# Altium schematic sheet Power v0.1.SchDoc
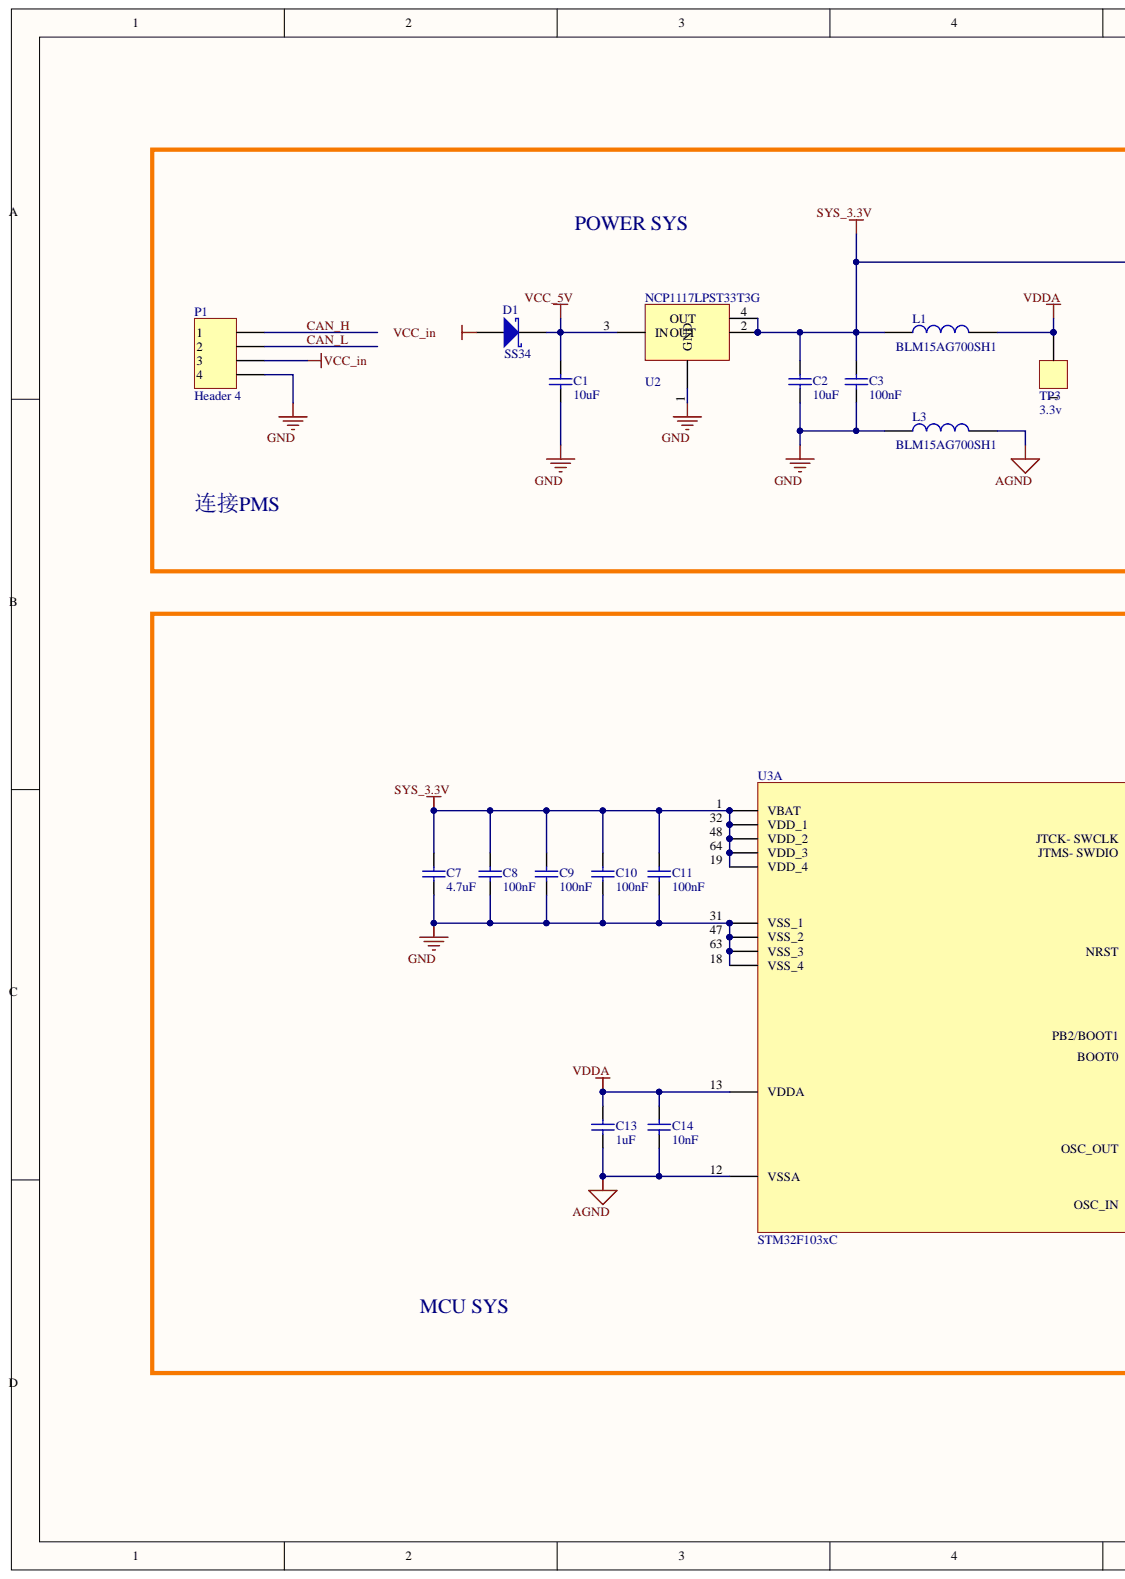
[diagram p0: Power v0.1.SchDoc, left]
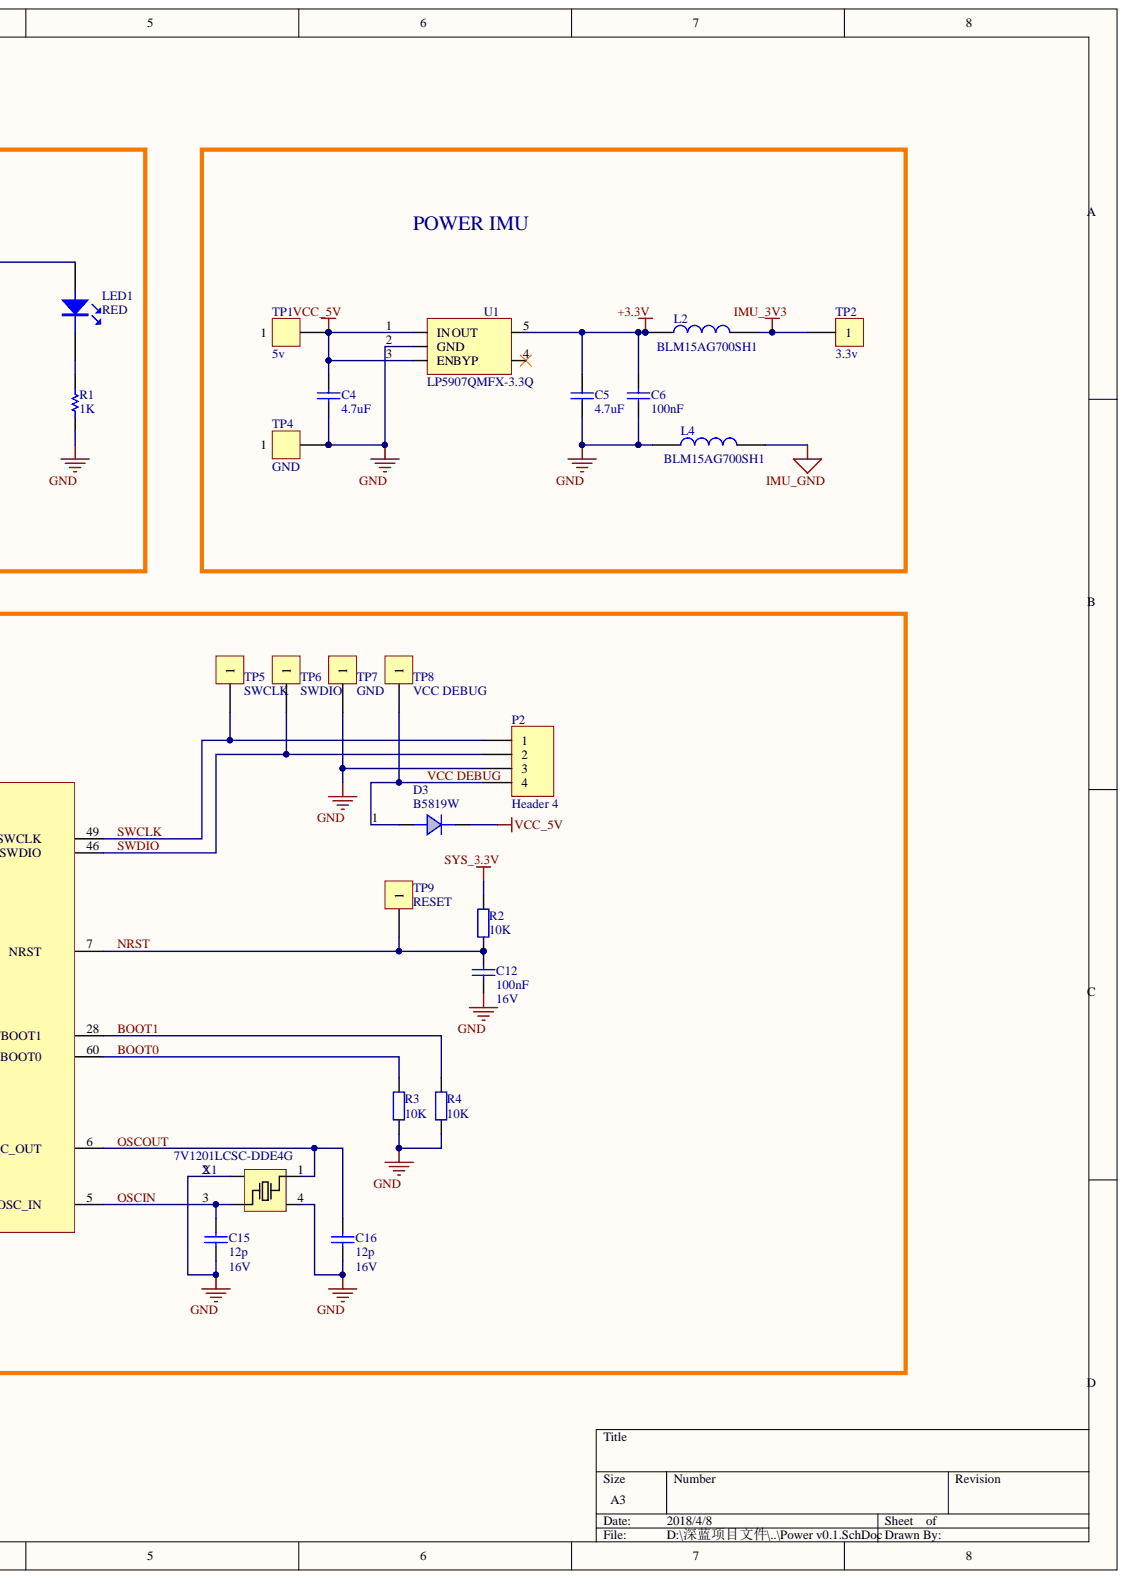
[diagram p1: Power v0.1.SchDoc, right]

## Parts
C1  value 10uF  [CAPC2012N]  (hidden comment: Cap)
C2  value 10uF  [CAPC1608N]  (hidden comment: Cap)
C3  value 100nF  [CAPC1608N]  (hidden comment: Cap)
C4  value 4.7uF  [CAPC1608N]  (hidden comment: Cap)
C5  value 4.7uF  [CAPC1608N]  (hidden comment: Cap)
C6  value 100nF  [CAPC1608N]  (hidden comment: Cap)
C7  value 4.7uF  [CAPC1608N]  (hidden comment: Cap)
C8  value 100nF  [CAPC1608N]  (hidden comment: Cap)
C9  value 100nF  [CAPC1608N]  (hidden comment: Cap)
C10  value 100nF  [CAPC1608N]  (hidden comment: Cap)
C11  value 100nF  [CAPC1608N]  (hidden comment: Cap)
C12  value 16V  value 100nF  [CAPC1608N]  (hidden comment: Cap)
C13  value 1uF  [CAPC1608N]  (hidden comment: Cap)
C14  value 10nF  [CAPC1608N]  (hidden comment: Cap)
C15  value 16V  value 12p  [CAPC1608N]  (hidden comment: Cap)
C16  value 16V  value 12p  [CAPC1608N]  (hidden comment: Cap)
D1  value SS34  [SMA]  (hidden comment: D Schottky)
D3  B5819W  [SOD-323]
L1  BLM15AG700SH1  [INDC1608N]
L2  BLM15AG700SH1  [INDC1608N]
L3  BLM15AG700SH1  [INDC1608N]
L4  BLM15AG700SH1  [INDC1608N]
LED1  RED  [LED_0603]
P1  Header 4  [1.25-4P-WT]
P2  Header 4  [1.25-4P-WT]
R1  value 1K  [RESC1608N]
R2  value 10K  [RESC1608N]  (hidden comment: Res2)
R3  value 10K  [RESC1608N]  (hidden comment: Res2)
R4  value 10K  [RESC1608N]  (hidden comment: Res2)
TP1  5v  [TP1.0-1]
TP2  3.3v  [TP1.0-1]
TP3  3.3v  [TP1.0-1]
TP4  GND  [TP1.0-1]
TP5  SWCLK  [TP1.0-1]
TP6  SWDIO  [TP1.0-1]
TP7  GND  [TP1.0-1]
TP8  VCC DEBUG  [TP1.0-1]
TP9  RESET  [TP1.0-1]
U1  LP5907QMFX-3.3Q  [SOT23-5]
U2  NCP1117LPST33T3G  [SOT223-4N]
U3  STM32F103xC  [TSQFP50P1200X1200-64M]  (part A)
X1  7V1201LCSC-DDE4G  [XTAL3225-4P]

## Nets
+3.3V: C5.1 C6.2 L2.1 U1.5
AGND: C13.2 C14.2 L3.2 U3.12(VSSA)
GND: C1.2 C2.2 C3.1 C4.2 C5.2 C6.1 C7.2 C8.2 C9.2 C10.2 C11.2 C12.2 C15.1 C16.1 L3.1 L4.1 P1.4 P2.3 R1.1 R3.1 R4.1 TP4.1 TP7.1 U1.2(GND) U2.1(GND) U3.18(VSS_4) U3.31(VSS_1) U3.47(VSS_2) U3.63(VSS_3) X1.2 X1.4
IMU_3V3: L2.2 TP2.1
IMU_GND: L4.2
SYS_3.3V: C2.1 C3.2 C7.1 C8.1 C9.1 C10.1 C11.1 L1.1 LED1.1 R2.2 U2.2 U2.4 U3.1(VBAT) U3.19(VDD_4) U3.32(VDD_1) U3.48(VDD_2) U3.64(VDD_3)
VCC_5V: C1.1 C4.1 D1.2 D3.2 TP1.1 U1.1(IN_OUT) U1.3(EN_BYP) U2.3(IN_OUT)
VCC_IN: D1.1 P1.3
VDDA: C13.1 C14.1 L1.2 TP3.1 U3.13(VDDA)
BOOT0: R3.2 U3.60
BOOT1: R4.2 U3.28
CAN_H: P1.1
CAN_L: P1.2
NRST: C12.1 R2.1 TP9.1 U3.7
OSCIN: C15.2 U3.5 X1.3
OSCOUT: C16.2 U3.6 X1.1
SWCLK: P2.1 TP5.1 U3.49
SWDIO: P2.2 TP6.1 U3.46
VCC DEBUG: D3.1 P2.4 TP8.1
NetLED1_2: LED1.2 R1.2

Not connected: U1 4

## Library data of the parts
C1-C16 = Cap: Capacitor; Component Kind: Standard
  C1-C11 C13 C14: LatestRevisionDate: 17-Jul-2002; LatestRevisionNote: Re-released for DXP Platform.; Library Name: Miscellaneous Devices.IntLib; PackageDescription: Radial Cap, Thru-Hole; 2 Leads; 0.3 in Pin Spacing; PackageReference: RAD-0.3; Published: 8-Jun-2000; Signal Integrity: Cap; Simulation: CAP; Publisher: Altium Limited
  C12 C15 C16: Library Name: Schlib_002.SchLib
D1 = D Schottky: Schottky Diode; Code_JEDEC: DO-214-AA; Component Kind: Standard; LatestRevisionDate: 17-Jul-2002; LatestRevisionNote: Re-released for DXP Platform.; Library Name: Miscellaneous Devices.IntLib; PackageDescription: DO-214-AA/SMB; 2 C-Bend Leads; Body 5.3 x 3.6 mm, inc. leads (LxW); PackageReference: SMB; Published: 8-Jun-2000; Signal Integrity: D Schottky; Simulation: SKYDIODE; Publisher: Altium Limited
D3 = D_1N4148WS: Manufacturer: Diodes; Component Kind: Standard; Pin Count: 2; Supplier: SZ-JLC; value: B5819W; Library Name: F5-Abilix-v0.1.SCHLIB
L1-L4 = INDUCTOR: DC Resistance: 0.15Ohm; Impedance: 70Ohm; Rated Current: 500mA; Component Kind: Standard; Library Name: F5 GPS V01.IntLib
LED1 = LIGHT: Component Kind: Standard; Library Name: YUNEEC-SCH.lib
P1 P2 = Header 4: Header, 4-Pin; Component Kind: Standard; LatestRevisionDate: 17-Jul-2002; LatestRevisionNote: Re-released for DXP Platform.; Library Name: Miscellaneous Connectors.IntLib; Signal Integrity: Connector; Publisher: Altium Limited
R1 = Res1: Resistor; Component Kind: Standard; LatestRevisionDate: 17-Jul-2002; LatestRevisionNote: Re-released for DXP Platform.; Library Name: Miscellaneous Devices.IntLib; PackageDescription: Axial Device, Thru-Hole; 2 Leads; 0.3 in Pin Spacing; PackageReference: AXIAL-0.3; Published: 8-Jun-2000; Signal Integrity: Res; Simulation: RESISTOR; Publisher: Altium Limited
R2-R4 = Res2: Resistor; Component Kind: Standard; LatestRevisionDate: 17-Jul-2002; LatestRevisionNote: Re-released for DXP Platform.; Library Name: Miscellaneous Devices.IntLib; PackageDescription: Axial Device, Thru-Hole; 2 Leads; 0.4 in Pin Spacing; PackageReference: AXIAL-0.4; Published: 8-Jun-2000; Signal Integrity: Res; Simulation: RESISTOR; Publisher: Altium Limited
TP1-TP9 = CON1: Connector; Component Kind: Standard
U1 = MIC5205-3.3M: Component Kind: Standard; value: LP5907QMFX-3.3Q
U2 = 1117: Component Kind: Standard; value: NCP1117LPST33T3G; Library Name: My_shlib.SchLib
U3 = STM32F103xC: value: STM32F103RCT6; Component Kind: Standard; Library Name: My_shlib.SchLib
X1 = NX3225SA: Component Kind: Standard; value: 7V1201LCSC-DDE4G; Library Name: My_shlib.SchLib
